# Altium schematic sheet 8.SYS_PWR.SchDoc
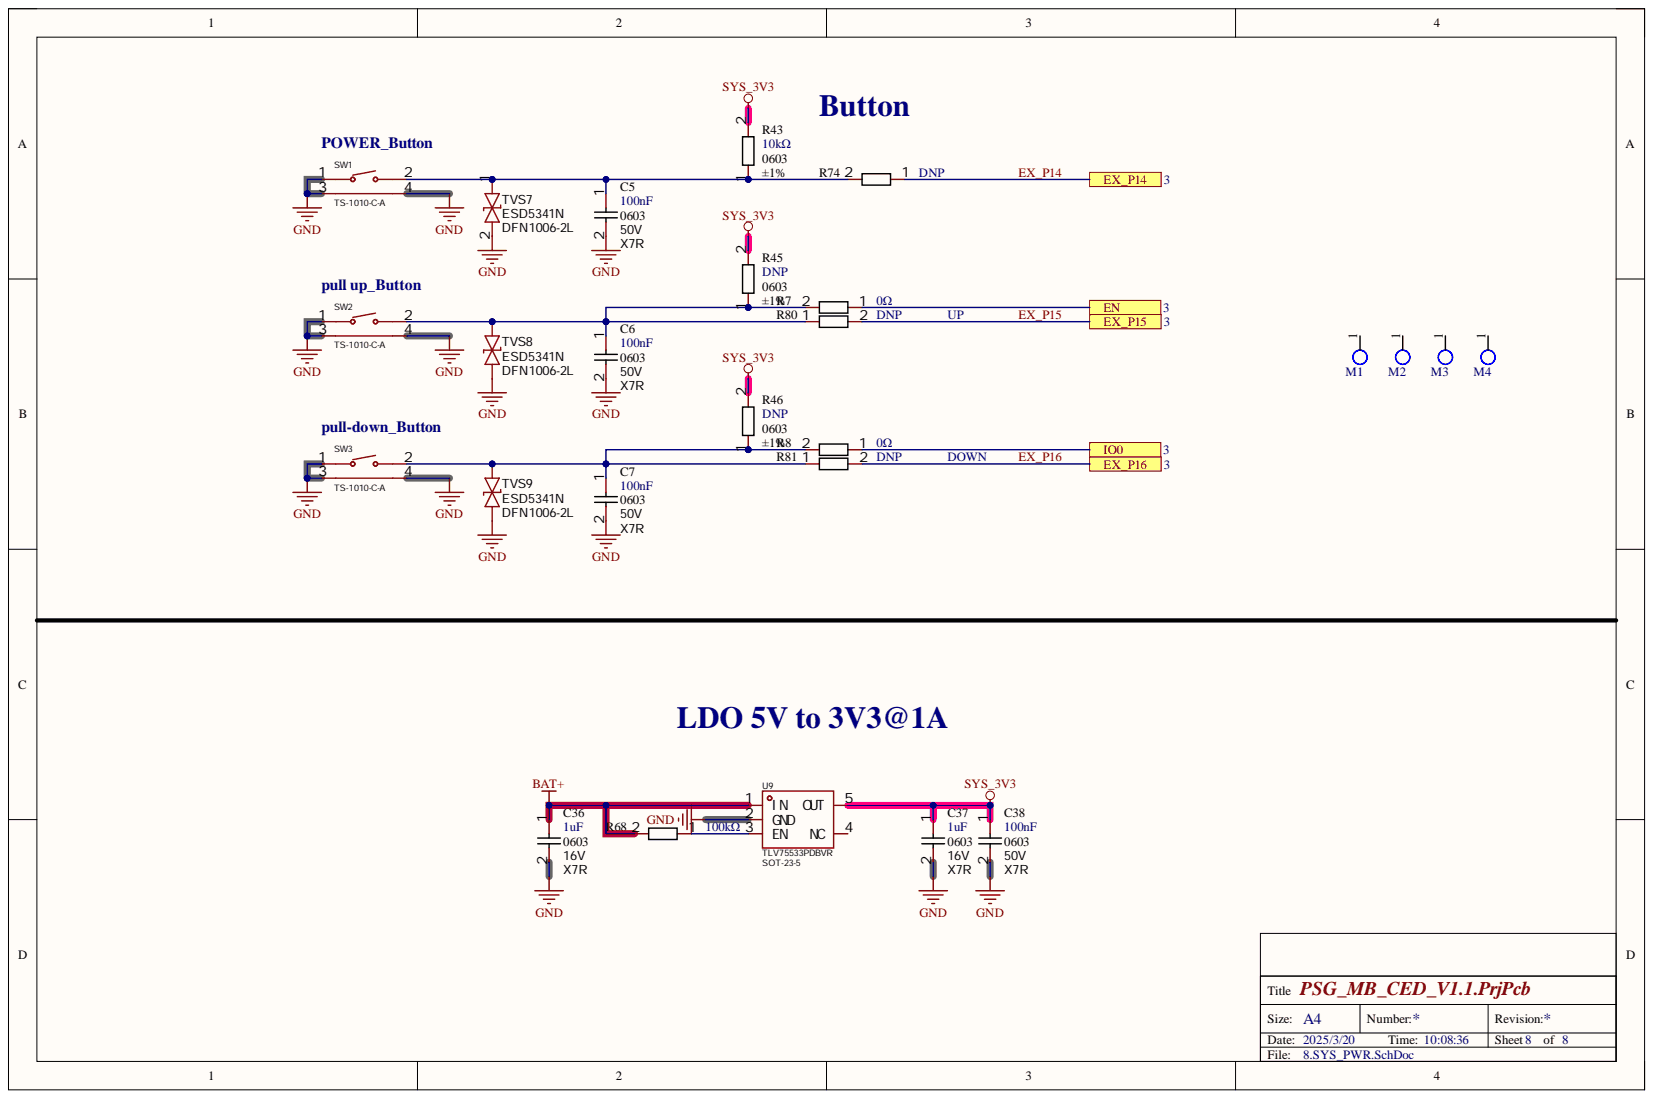
[diagram p0: 8.SYS_PWR.SchDoc]

## Parts
C5  100nF  Supplier Footprint: 0603  Temperature Coefficient: X7R  Voltage Rated: 50V  [C0603]
C6  100nF  Supplier Footprint: 0603  Temperature Coefficient: X7R  Voltage Rated: 50V  [C0603]
C7  100nF  Supplier Footprint: 0603  Temperature Coefficient: X7R  Voltage Rated: 50V  [C0603]
C36  1uF  Supplier Footprint: 0603  Temperature Coefficient: X7R  Voltage Rated: 16V  [C0603]
C37  1uF  Supplier Footprint: 0603  Temperature Coefficient: X7R  Voltage Rated: 16V  [C0603]
C38  100nF  Supplier Footprint: 0603  Temperature Coefficient: X7R  Voltage Rated: 50V  [C0603]
M1  ME  [MARK_1R0]  (value not drawn)
M2  ME  [MARK_1R0]  (value not drawn)
M3  ME  [MARK_1R0]  (value not drawn)
M4  ME  [MARK_1R0]  (value not drawn)
R7  0Ω  [R0603]
R8  0Ω  [R0603]
R43  10kΩ  Supplier Footprint: 0603  Tolerance: ±1%  [R0603]
R45  DNP  [R0603]
R46  DNP  [R0603]
R68  100kΩ  [R0603]
R74  DNP  [R0603]
R80  DNP  [R0603]
R81  DNP  [R0603]
SW1  TS-1010-C-A  [KEY-SMD_4P2Z_2R3X4R7X3R5]
SW2  TS-1010-C-A  [KEY-SMD_4P2Z_2R3X4R7X3R5]
SW3  TS-1010-C-A  [KEY-SMD_4P2Z_2R3X4R7X3R5]
TVS7  ESD5341N  Supplier Footprint: DFN1006-2L  [DFN1006-2L-BI]
TVS8  ESD5341N  Supplier Footprint: DFN1006-2L  [DFN1006-2L-BI]
TVS9  ESD5341N  Supplier Footprint: DFN1006-2L  [DFN1006-2L-BI]
U9  TLV75533PDBVR  Supplier Footprint: SOT-23-5  [SOT_23_5]

## Ports
EN, EX_P14, EX_P15, EX_P16, IO0

## Nets
BAT+: C36.1 R68.2 U9.1(IN)
GND: C5.2 C6.2 C7.2 C36.2 C37.2 C38.2 SW1.1 SW1.3 SW1.4 SW2.1 SW2.3 SW2.4 SW3.1 SW3.3 SW3.4 TVS7.2 TVS8.2 TVS9.2 U9.2(GND)
SYS_3V3: C37.1 C38.1 R43.2 R45.2 R46.2 U9.5(OUT)
EN: R7.1 ; port EN
EX_P14: R74.1 ; port EX_P14
EX_P15: R80.2 ; port EX_P15
EX_P16: R81.2 ; port EX_P16
IO0: R8.1 ; port IO0
NetC5_1: C5.1 R43.1 R74.2 SW1.2 TVS7.1
NetC6_1: C6.1 R7.2 R45.1 R80.1 SW2.2 TVS8.1
NetC7_1: C7.1 R8.2 R46.1 R81.1 SW3.2 TVS9.1
NetR68_1: R68.1 U9.3(EN)

Not connected: M1 1; M2 1; M3 1; M4 1; U9 4(NC)

## Library data of the parts
C5-C7 C38 = CC0603JRNPO9BN120: Manufacturer: YAGEO(国巨); Add into BOM: yes; Device: CC0603KRX7R9BB104; Name: 贴片电容; Tolerance: ±10%; Component Kind: Standard; Convert to PCB: yes; Datasheet: https://atta.szlcsc.com/upload/public/pdf/source/20180910/C282072_33301C7F631E79921B82A8DAC6BCC5B3.pdf; JLCPCB Part Class: Basic Part; LCSC Part Name: 100nF ±10% 50V; Manufacturer Part: CC0603KRX7R9BB104; Supplier: LCSC; Supplier Part: C14663; Symbol: CC0603KRX7R9BB104; Value: 100nF
C36 C37 = CC0603JRNPO9BN120: Manufacturer: YAGEO(国巨); Add into BOM: yes; Device: CC0603KRX7R7BB105; Name: 贴片电容; Tolerance: ±10%; Component Kind: Standard; Convert to PCB: yes; Datasheet: https://atta.szlcsc.com/upload/public/pdf/source/20180910/C282072_33301C7F631E79921B82A8DAC6BCC5B3.pdf; JLCPCB Part Class: Extended Part; LCSC Part Name: 1uF ±10% 16V; Manufacturer Part: CC0603KRX7R7BB105; Supplier: LCSC; Supplier Part: C106248; Symbol: CC0603KRX7R7BB105; Value: 1uF
M1-M4 = TESTPOINT-DIP: PAD; Part_Number: TP-DIP; Part_Type: TEST; ID: 4; Page_Name: 11-CPU POWER; Parameter: 独立过孔式测试点; PCB DECAL: TP100D60; Source_Path: \\DSERVER.ARTMEM.COM\AIC\DEPT\SD\SHARE\CADENCE CIS\LIBRARY\OLB\OTHERS.OLB; Component Kind: Standard; Value: ME
R7 R8 R74 = 0603WAF1003T5E: Manufacturer: YAGEO(国巨); Add into BOM: yes; Device: RC0603FR-070RL; Name: 贴片电阻; Overload Voltage (Max): 75V; Power(Watts): 100mW; Component Kind: Standard; Convert to PCB: yes; Datasheet: https://atta.szlcsc.com/upload/public/pdf/source/20180316/70C0E2BDAE7FCC62C531A5A58D202EE1.pdf; JLCPCB Part Class: Extended Part; LCSC Part Name: 厚膜电阻 0Ω ±1% 100mW; Manufacturer Part: RC0603FR-070RL; Operating Temperature Range: -55℃~+155℃; Supplier: LCSC; Supplier Part: C100044; Symbol: RC0603FR-070RL; Temperature Coefficient: -; Type: 厚膜电阻
  R7 R8: Value: 0Ω
  R74: Value: DNP
R43 = 0603WAF1003T5E: Manufacturer: YAGEO(国巨); Add into BOM: yes; Device: RC0603FR-0710KL; Name: 贴片电阻; Overload Voltage (Max): 75V; Power(Watts): 100mW; Temperature Coefficient: ±100ppm/℃; Component Kind: Standard; Convert to PCB: yes; Datasheet: https://atta.szlcsc.com/upload/public/pdf/source/20180927/C295883_E8D83085EA81BD7DE0B2B681138C15EE.pdf; JLCPCB Part Class: Extended Part; LCSC Part Name: 厚膜电阻 10kΩ ±1% 100mW; Manufacturer Part: RC0603FR-0710KL; Operating Temperature Range: -55℃~+155℃; Supplier: LCSC; Supplier Part: C98220; Symbol: RC0603FR-0710KL; Type: 厚膜电阻; Value: 10kΩ
R45 R46 = 0603WAF1003T5E: Manufacturer: YAGEO(国巨); Add into BOM: yes; Device: RC0603FR-0710KL; Name: 贴片电阻; Overload Voltage (Max): 75V; Power(Watts): 100mW; Temperature Coefficient: ±100ppm/℃; Tolerance: ±1%; Component Kind: Standard; Convert to PCB: yes; Datasheet: https://atta.szlcsc.com/upload/public/pdf/source/20180927/C295883_E8D83085EA81BD7DE0B2B681138C15EE.pdf; JLCPCB Part Class: Extended Part; LCSC Part Name: 厚膜电阻 10kΩ ±1% 100mW; Manufacturer Part: RC0603FR-0710KL; Operating Temperature Range: -55℃~+155℃; Supplier: LCSC; Supplier Footprint: 0603; Supplier Part: C98220; Symbol: RC0603FR-0710KL; Type: 厚膜电阻; Value: DNP
R68 = 0603WAF1003T5E: Manufacturer: YAGEO(国巨); Add into BOM: yes; Device: RC0603FR-07100KL; Name: 贴片电阻; Overload Voltage (Max): 75V; Power(Watts): 100mW; Temperature Coefficient: ±100ppm/℃; Tolerance: ±1%; Component Kind: Standard; Convert to PCB: yes; Datasheet: https://atta.szlcsc.com/upload/public/pdf/source/20140324/1457707028752.pdf; JLCPCB Part Class: Extended Part; LCSC Part Name: 厚膜电阻 100kΩ ±1% 100mW; Manufacturer Part: RC0603FR-07100KL; Operating Temperature Range: -55℃~+155℃; Supplier: LCSC; Supplier Footprint: 0603; Supplier Part: C14675; Symbol: RC0603FR-07100KL; Type: 厚膜电阻; Value: 100kΩ
R80 R81 = 0603WAF1003T5E: Manufacturer: YAGEO(国巨); Add into BOM: yes; Device: RC0603FR-07510RL; Name: 贴片电阻; Overload Voltage (Max): 75V; Power(Watts): 100mW; Temperature Coefficient: ±100ppm/℃; Component Kind: Standard; Convert to PCB: yes; Datasheet: https://atta.szlcsc.com/upload/public/pdf/source/20170914/C127450_1505359406912967677.pdf; JLCPCB Part Class: Extended Part; LCSC Part Name: 厚膜电阻 510Ω ±1% 100mW; Manufacturer Part: RC0603FR-07510RL; Operating Temperature Range: -55℃~+155℃; Supplier: LCSC; Supplier Part: C114670; Symbol: RC0603FR-07510RL; Type: 厚膜电阻; Value: DNP
SW1-SW3 = TS-1010-C-A: Manufacturer: XKB Connectivity(中国星坤); Actuator Style: 矩形按钮; Actuator/Cap Color: 黑色; Add into BOM: yes; Circuit: 单刀单掷; Contact Current: 50mA; Mechanical Life: 10万次; Operating Force: 260gf; Strike Gundam: 不带; Switch Height: 3.5mm; Switch Length: 2.3mm; Switch Width: 4.7mm; Voltage Rating (DC): 12V; With Lamp: 无; Component Kind: Standard; Convert to PCB: yes; Datasheet: https://atta.szlcsc.com/upload/public/pdf/source/20211011/09621D275CE0F472C941775DFBBCCC96.pdf; Insulation Resistance: -; IP Rating: -; JLCPCB Part Class: Extended Part; LCSC Part Name: 2.3*4.7*3.5mm 卧贴 轻触开关; Manufacturer Part: TS-1010-C-A; Mounting Style: 卧贴; Operating Temperature: -30℃~+85℃; PCB3D: KEY-SMD_L4.6-W2.3-H1.8-P3.4; Pin Style: -; Power Rating: -; Supplier: LCSC; Supplier Footprint: SMD; Supplier Part: C692458; Symbol: TS-1010-C-A; Value: TS-1010-C-A; Voltage Rating (AC): -
TVS7-TVS9 = ESD5341N_C20612964: Manufacturer: KUU; Add into BOM: yes; Component Kind: Standard; Convert to PCB: yes; Datasheet: https://atta.szlcsc.com/upload/public/pdf/source/20240126/9EE8C033ACD76A81C21292FAA1DFE4C1.pdf; JLCPCB Part Class: Extended Part; LCSC Part Name: ESD静电保护管; Manufacturer Part: ESD5341N; Supplier: LCSC; Supplier Part: C20612964; Symbol: ESD5341N_C20612964; Value: ESD5341N
U9 = TLV75533PDBVR: Manufacturer: TI(德州仪器); Add into BOM: yes; Dropout Voltage: 238mV@(500mA); Maximum Input Voltage: 5.5V; Number of Outputs: 1; Output Current: 500mA; Output Polarity: 正极; Output Type: 固定; Output Voltage: 3.3V; Power Supply Rejection Ratio (PSRR): 52dB; standby current: 31uA; Component Kind: Standard; Convert to PCB: yes; Datasheet: https://www.ti.com/cn/lit/gpn/tlv755p; Feature: 防静电保护；浪涌保护；低ESR；热保护(TSD)；欠压闭锁；过流保护(OCP)；带使能; JLCPCB Part Class: Extended Part; LCSC Part Name: 具有使能功能的 500mA、高 PSRR、低 IQ、低压降稳压器; Manufacturer Part: TLV75533PDBVR; Operating Temperature: -40℃~+125℃@(Tj); PCB3D: SOT-23-5_L2.9-W1.6-H1.1-LS2.8-P0.95; Supplier: LCSC; Supplier Part: C404027; Symbol: TLV75533PDBVR; Value: TLV75533PDBVR
